# Altium schematic sheet BLE-NTC.SchDoc
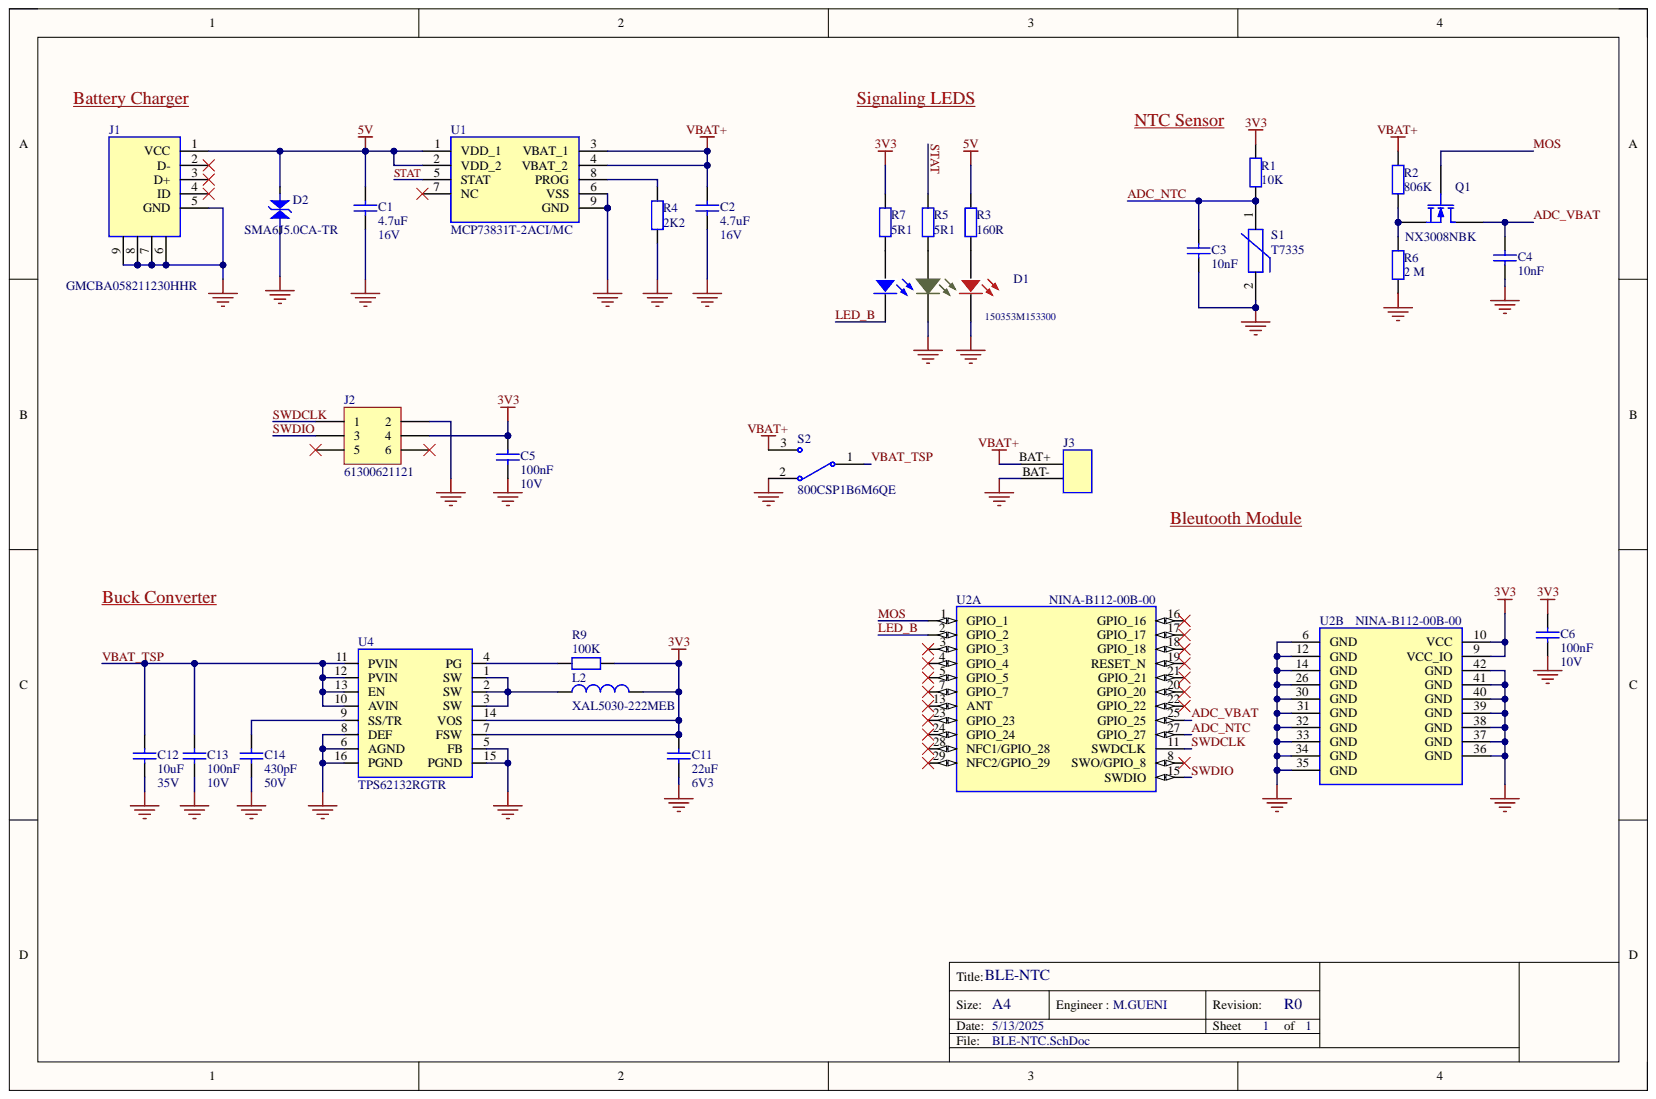
[diagram p0: BLE-NTC.SchDoc]

## Parts
C1  Value 1: 4.7uF  Value 2: 16V  [CAPC1608N]  (hidden comment: Capacitor)
C2  Value 1: 4.7uF  Value 2: 16V  [CAPC1608N]  (hidden comment: Capacitor)
C3  Value 1: 10nF  [CAPC1608N]  (hidden comment: Capacitor)
C4  Value 1: 10nF  [CAPC1608N]  (hidden comment: Capacitor)
C5  Value 1: 100nF  Value 2: 10V  [CAPC1608N]  (hidden comment: Capacitor)
C6  Value 1: 100nF  Value 2: 10V  [CAPC1608N]  (hidden comment: Capacitor)
C11  Value 1: 22uF  Value 2: 6V3  [CAPC1608N]  (hidden comment: Capacitor)
C12  Value 1: 10uF  Value 2: 35V  [CAPC1608N]  (hidden comment: Capacitor)
C13  Value 1: 100nF  Value 2: 10V  [CAPC1608N]  (hidden comment: Capacitor)
C14  Value 1: 430pF  Value 2: 50V  [CAPC1608N]  (hidden comment: Capacitor)
D1  150353M153300  [150353M153300]
D2  SMA6J5.0CA-TR  [SMA6J5.0CA-TR]
J1  GMCBA058211230HHR  [GMCBA058211230HHR]
J2  61300621121  [HDR2X3]
J3  BAT_PAD  [BAT_PAD]  (value not drawn)
L2  XAL5030-222MEB  [22uh-XAL5030]
Q1  NX3008NBK  [SOT23-3N]
R1  Value 1: 10K  [RESC1608N]  (hidden comment: Resistor)
R2  Value 1: 806K  [RESC1608N]  (hidden comment: Resistor)
R3  Value 1: 160R  [RESC1608N]  (hidden comment: Resistor)
R4  Value 1: 2K2  [RESC1608N]  (hidden comment: Resistor)
R5  Value 1: 5R1  [RESC1608N]  (hidden comment: Resistor)
R6  Value 1: 2 M  [RESC1608N]  (hidden comment: Resistor)
R7  Value 1: 5R1  [RESC1608N]  (hidden comment: Resistor)
R9  Value 1: 100K  [RESC1608N]  (hidden comment: Resistor)
S1  T7335  [T7335D]
S2  800CSP1B6M6QE  [800CSP1B6M6QE]
U1  MCP73831T-2ACI/MC  [mcp-SON50P300X200X100-9N-D]
U2  NINA-B112-00B-00  [NINAB112]  (parts A,B)
U4  TPS62132RGTR  [tps62132]

## Nets
3V3: C5.2 C6.2 C11.2 J2.4 L2.2 R1.2 R7.2 R9.2 U2.9(VCC_IO) U2.10(VCC) U4.7(FSW) U4.14(VOS)
5V: C1.1 D2.2 J1.1(VCC) R3.2 U1.1(VDD_1) U1.2(VDD_2)
GND: C1.2 C2.2 C3.1 C4.1 C5.1 C6.1 C11.1 C12.1 C13.1 C14.1 D1.2 D1.4 D2.1 J1.5(GND) J1.6 J1.7 J1.8 J1.9 J2.2 J3.BAT- R4.2 R6.2 S1.2 S2.2 U1.6(VSS) U1.9(GND) U2.6(GND) U2.12(GND) U2.14(GND) U2.26(GND) U2.30(GND) U2.31(GND) U2.32(GND) U2.33(GND) U2.34(GND) U2.35(GND) U2.36(GND) U2.37(GND) U2.38(GND) U2.39(GND) U2.40(GND) U2.41(GND) U2.42(GND) U4.5(FB) U4.6(AGND) U4.8(DEF) U4.15(PGND) U4.16(PGND)
VBAT+: C2.1 J3.BAT+ R2.2 S2.3 U1.3(VBAT_1) U1.4(VBAT_2)
ADC_NTC: C3.2 R1.1 S1.1 U2.27(GPIO_27)
ADC_VBAT: C4.2 Q1.3 U2.25(GPIO_25)
LED_B: D1.6 U2.2(GPIO_2)
MOS: Q1.1 U2.1(GPIO_1)
STAT: R5.2 U1.5(STAT)
SWDCLK: J2.1 U2.11(SWDCLK)
SWDIO: J2.3 U2.15(SWDIO)
VBAT_TSP: C12.2 C13.2 S2.1 U4.10(AVIN) U4.11(PVIN) U4.12(PVIN) U4.13(EN)
NetC14_2: C14.2 U4.9(SS/TR)
NetD1_1: D1.1 R3.1
NetD1_3: D1.3 R5.1
NetD1_5: D1.5 R7.1
NetL2_1: L2.1 U4.1(SW) U4.2(SW) U4.3(SW)
NetQ1_2: Q1.2 R2.1 R6.1
NetR4_1: R4.1 U1.8(PROG)
NetR9_1: R9.1 U4.4(PG)

Not connected: J1 2(D-) 3(D+) 4(ID); J2 5 6; U1 7(NC); U2 3(GPIO_3) 4(GPIO_4) 5(GPIO_5) 7(GPIO_7) 8(SWO/GPIO_8) 13(ANT) 16(GPIO_16) 17(GPIO_17) 18(GPIO_18) 19(RESET_N) 20(GPIO_20) 21(GPIO_21) 22(GPIO_22) 23(GPIO_23) 24(GPIO_24) 28(NFC1/GPIO_28) 29(NFC2/GPIO_29)

## Library data of the parts
C1 C2 = CAP-000000047: Database Table Name: Ceramic Capacitor; DES: Multilayer Ceramic Capacitors MLCC - SMD/SMT 4.7UF 16V 10% 0603; FTP: 0603; MAN: Murata Electronics; MANREF: GRM188C71C475KE21D; NOTE: _; SUP: Mouser; SUPREF: 81-GRM188C71C475KE1D; TOL: 10%; Component Kind: Standard; Library Name: Database_Libs1.DbLib; Part Number: CAP-000000047
C3 C4 = CAP-000000003: Database Table Name: Ceramic Capacitor; DES: 06035C103JAT2A -  Condensateur céramique multicouche CMS, 10000 pF, 50 V, 0603 [1608 Metric], ± 5%, X7R; FTP: 0603; MAN: MULTICOMP; MANREF: 06035C103JAT2A; NOTE: _; SUP: Farnell; SUPREF: 1833871; TOL: 5%; Value 2: 50V; Component Kind: Standard; Library Name: Database_Libs1.DbLib; Part Number: CAP-000000003
C5 C6 C13 = CAP-000000005: Database Table Name: Ceramic Capacitor; DES: C0603X104K8RACTU -  Condensateur céramique multicouche CMS, 0.1 µF, 10 V, 0603 [1608 Metric], ± 10%, X7R; FTP: 0603; MAN: KEMET; MANREF: C0603X104K8RACTU; NOTE: _; SUP: Farnell; SUPREF: 2904937; TOL: 10%; Component Kind: Standard; Library Name: Database_Libs1.DbLib; Part Number: CAP-000000005
C11 = CAP-000000045: Database Table Name: Ceramic Capacitor; DES: Multilayer Ceramic Capacitors MLCC - SMD/SMT 0603 22uF 6.3volts *Derate Voltage/Temp; FTP: 0603; MAN: Murata Electronics; MANREF: GRM188R60J226MEA0D; NOTE: In Stock; SUP: Mouser; SUPREF: 81-GRM188R60J226ME0D; TOL: 20%; Component Kind: Standard; Library Name: Database_Libs1.DbLib; Part Number: CAP-000000045
C12 = CAP-000000006: Database Table Name: Ceramic Capacitor; DES: Multilayer Ceramic Capacitors MLCC - SMD/SMT 0603 10uF 35volts *Derate Voltage/Temp; FTP: 0603; MAN: Murata Electronics; MANREF: GRM188R6YA106MA73D; NOTE: _; SUP: Mouser; SUPREF: 81-GRM188R6YA106MA3D; TOL: 20%; Component Kind: Standard; Library Name: Database_Libs1.DbLib; Part Number: CAP-000000006
C14 = CAP-000000044: Database Table Name: Ceramic Capacitor; DES: Multilayer Ceramic Capacitors MLCC - SMD/SMT 50V 430pF 0603 C0G 0.02; FTP: 0603; MAN: KEMET; MANREF: C0603C431G5GACTU; NOTE: In Stock; SUP: Mouser; SUPREF: 80-C0603C431G5G; TOL: 2%; Component Kind: Standard; Library Name: Database_Libs1.DbLib; Part Number: CAP-000000044
D1 = LED-000000004: Database Table Name: Optoelctronic; DES: WL-SFTW SMD Full-color TOP LED Waterclear, size 5050, Red & Green & Blue, 120deg; FTP: _; MAN: Wurth Elektronik; NOTE: In Stock; SUP: Mouser; SUPREF: 710-150353M153300; Value 1: 100 mA; Component Kind: Standard; Library Name: Database_Libs1.DbLib; MANREF: 150353M153300; Part Number: LED-000000004; TOL: _; Value 2: _
D2 = TVS-000000006: Database Table Name: ESD Suppressors / TVS Diodes; DES: ESD Suppressors / TVS Diodes HJ Temp Transil  ESD Suppressors / TVS Diodes HJ Temp Transil; FTP: SMA; MAN: STMicroelectronics; NOTE: In Stock; SUP: Mouser; SUPREF: 511-SMA6J5.0CA-TR; TOL: _; Value 1: 13.4 V; Value 2: 600W; Component Kind: Standard; Library Name: Database_Libs1.DbLib; MANREF: SMA6J5.0CA-TR; Part Number: TVS-000000006
J1 = CON-000000037: Database Table Name: Connectors; DES: USB Connectors USB MICRO B TYPE RECPT; FTP: SMD; MAN: Amphenol Commercial Products; NOTE: In Stock; SUP: Mouser; SUPREF: 523-GMCBA05821123HHR; TOL: _; Component Kind: Standard; Library Name: Database_Libs1.DbLib; MANREF: GMCBA058211230HHR; Part Number: CON-000000037; Value 1: _; Value 2: _
J2 = CON-000000012: Database Table Name: Connectors; DES: Embases et logements de câbles WR-PHD 2.54mm Hdr 6P Dual Str Gold; FTP: THT; MAN: Wurth Electronics; NOTE: In Stock; SUP: Mouser; SUPREF: 710-61300621121; TOL: _; Value 1: 6pts; Component Kind: Standard; Library Name: Database_Libs1.DbLib; MANREF: 61300621121; Part Number: CON-000000012; Value 2: _
J3 = MIS-000000024: Database Table Name: Miscellaneous; DES: _; Component Kind: Standard; FTP: _; Library Name: Database_Libs1.DbLib; MAN: _; MANREF: _; NOTE: _; Part Number: MIS-000000024; SUP: _; SUPREF: _; TOL: _; Value 1: _
L2 = IND-000000001: Database Table Name: Inductors; DES: Fixed Inductors 2.2uH 20% 9.7A 14.5mOhms AEC-Q200; FTP: _; MAN: Coilcraft; NOTE: In Stock; SUP: Mouser; SUPREF: 994-XAL5030-222MEB; TOL: 20 %; Value 1: 2.2uH; Value 2: 9.2 A; Component Kind: Standard; Library Name: Database_Libs1.DbLib; MANREF: XAL5030-222MEB; Part Number: IND-000000001
Q1 = TRM-000000001: Database Table Name: Transistors; DES: NXP  NX3008NBK  MOSFET, N CH, 30V, 400MA, SOT23; FTP: SOT-23; MAN: NXP; NOTE: In Stock; SUP: Farnell; SUPREF: 2069541; TOL: _; Value 1: 400mA; Value 2: 30V; Component Kind: Standard; Library Name: Database_Libs1.DbLib; MANREF: NX3008NBK; Part Number: TRM-000000001
R1 = RES-000000001: Database Table Name: Resistors; DES: YAGEO (PHYCOMP)  RC0603FR-0710KL  RESISTANCE COUCHE EPAISSE 10K 0.1W 1%; FTP: 0603; MAN: YAGEO; MANREF: RC0603FR-0710KL; NOTE: _; SUP: Farnell; SUPREF: 9238603; TOL: 1%; Value 2: 0.1W; Component Kind: Standard; Library Name: Database_Libs1.DbLib; Part Number: RES-000000001
R2 = RES-00000011s3: Database Table Name: Resistors; DES: Thick Film Resistors 806KOHM 1/10WATT 1%; FTP: 0603; MAN: Bourns; MANREF: CR0603-FX-8063ELF; NOTE: In Stock; SUP: Mouser; SUPREF: 652-CR0603-FX8063ELF; TOL: 1%; Value 2: 0.1W; Component Kind: Standard; Library Name: Database_Libs1.DbLib; Part Number: RES-00000011s3
R3 = RES-000000098: Database Table Name: Resistors; DES: Thick Film Resistors 1/10watt 160ohms 1%; FTP: 0603; MAN: Vishay / Dale; MANREF: CRCW0603160RFKEA; NOTE: In Stock; SUP: Mouser; SUPREF: 71-CRCW0603-160-E3; TOL: 1%; Value 2: 0,1W; Component Kind: Standard; Library Name: Database_Libs1.DbLib; Part Number: RES-000000098
R4 = RES-000000009: Database Table Name: Resistors; DES: YAGEO (PHYCOMP)  RC0603FR-072K2L  RESISTANCE COUCHE EPAISSE 2.2K 0.1W 1%; FTP: 0603; MAN: YAGEO; MANREF: RC0603FR-072K2L; NOTE: _; SUP: Farnell; SUPREF: 9238522; TOL: 1%; Value 2: 0.1W; Component Kind: Standard; Library Name: Database_Libs1.DbLib; Part Number: RES-000000009
R5 R7 = RES-000000097: Database Table Name: Resistors; DES: Thick Film Resistors 1/10watt 5.1ohms 5%; FTP: 0603; MAN: Vishay / Dale; MANREF: CRCW06035R10JNEA; NOTE: In Stock; SUP: Mouser; SUPREF: 71-CRCW0603J-5.1-E3; TOL: 5%; Value 2: 10W; Component Kind: Standard; Library Name: Database_Libs1.DbLib; Part Number: RES-000000097
R6 = RES-00000011d2: Database Table Name: Resistors; DES: Thin Film Resistors .1W 2Mohms 1% 0603 50ppm; FTP: 0603; MAN: Vishay / Beyschlag; MANREF: MCT06030C2004FP500; NOTE: In Stock; SUP: Mouser; SUPREF: 594-MCT06030C2004FP5; TOL: 1%; Value 2: 0.125W; Component Kind: Standard; Library Name: Database_Libs1.DbLib; Part Number: RES-00000011d2
R9 = RES-000000038: Database Table Name: Resistors; DES: YAGEO (PHYCOMP)  RC0603FR-07100KL  RESISTANCE COUCHE EPAISSE 100K 0.1W 1%; FTP: 0603; MAN: YAGEO; MANREF: RC0603FR-07100KL; NOTE: _; SUP: Farnell; SUPREF: 9238727; TOL: 1%; Value 2: 0.1W; Component Kind: Standard; Library Name: Database_Libs1.DbLib; Part Number: RES-000000038
S1 = MIS-000000023: Database Table Name: Miscellaneous; DES: Negative temperature coefficient thermistor; FTP: _; MAN: Honeywell; MANREF: T7335 D1008; Component Kind: Standard; Library Name: Database_Libs1.DbLib; NOTE: _; Part Number: MIS-000000023; SUP: _; SUPREF: _; TOL: _; Value 1: _; Value 2: _
S2 = SWC-000000006: Database Table Name: Switch; DES: Pushbutton Switches SPDT ON-ON PC MNT; FTP: _; MAN: E-Switch; Component Kind: Standard; Library Name: Database_Libs1.DbLib; MANREF: 800CSP1B6M6QE; NOTE: _; Part Number: SWC-000000006; SUP: E-Switch; SUPREF: 800CSP1B6M6QE; TOL: _; Value 1: _; Value 2: _
U1 = ICP-000000011: Database Table Name: Power Management IC; DES: Battery Management Charge mgnt contr    Battery Management Charge mgnt contr; FTP: DFN; MAN: Microchip Technology; NOTE: In Stock; SUP: Mouser; SUPREF: 579-MCP73831T-2ACIMC; TOL: _; Component Kind: Standard; Library Name: Database_Libs1.DbLib; MANREF: MCP73831T-2ACI/MC; Part Number: ICP-000000011; Value 1: _; Value 2: _
U2 = RFC-000000004: Database Table Name: RF; DES: MOD BLE 5.0 NORDIC NRF52832 SOC; FTP: _; MAN: U-Blox; NOTE: In Stock; SUP: Digi-Key; SUPREF: 672-NINA-B112-00B-00CT-ND; Component Kind: Standard; Library Name: Database_Libs1.DbLib; MANREF: NINA-B112-00B-00; Part Number: RFC-000000004; TOL: _; Value 1: _; Value 2: _
U4 = ICP-000000010: Database Table Name: Power Management IC; DES: Switching Voltage Regulators 3-17V 3A SD Cnvrtr; FTP: VQFN-16; MAN: Texas Instruments; NOTE: In Stock; SUP: Mouser; SUPREF: 595-TPS62132RGTR; TOL: _; Component Kind: Standard; Library Name: Database_Libs1.DbLib; MANREF: TPS62132RGTR; Part Number: ICP-000000010; Value 1: _; Value 2: _
